# Altium schematic sheet Light Sensor.SchDoc
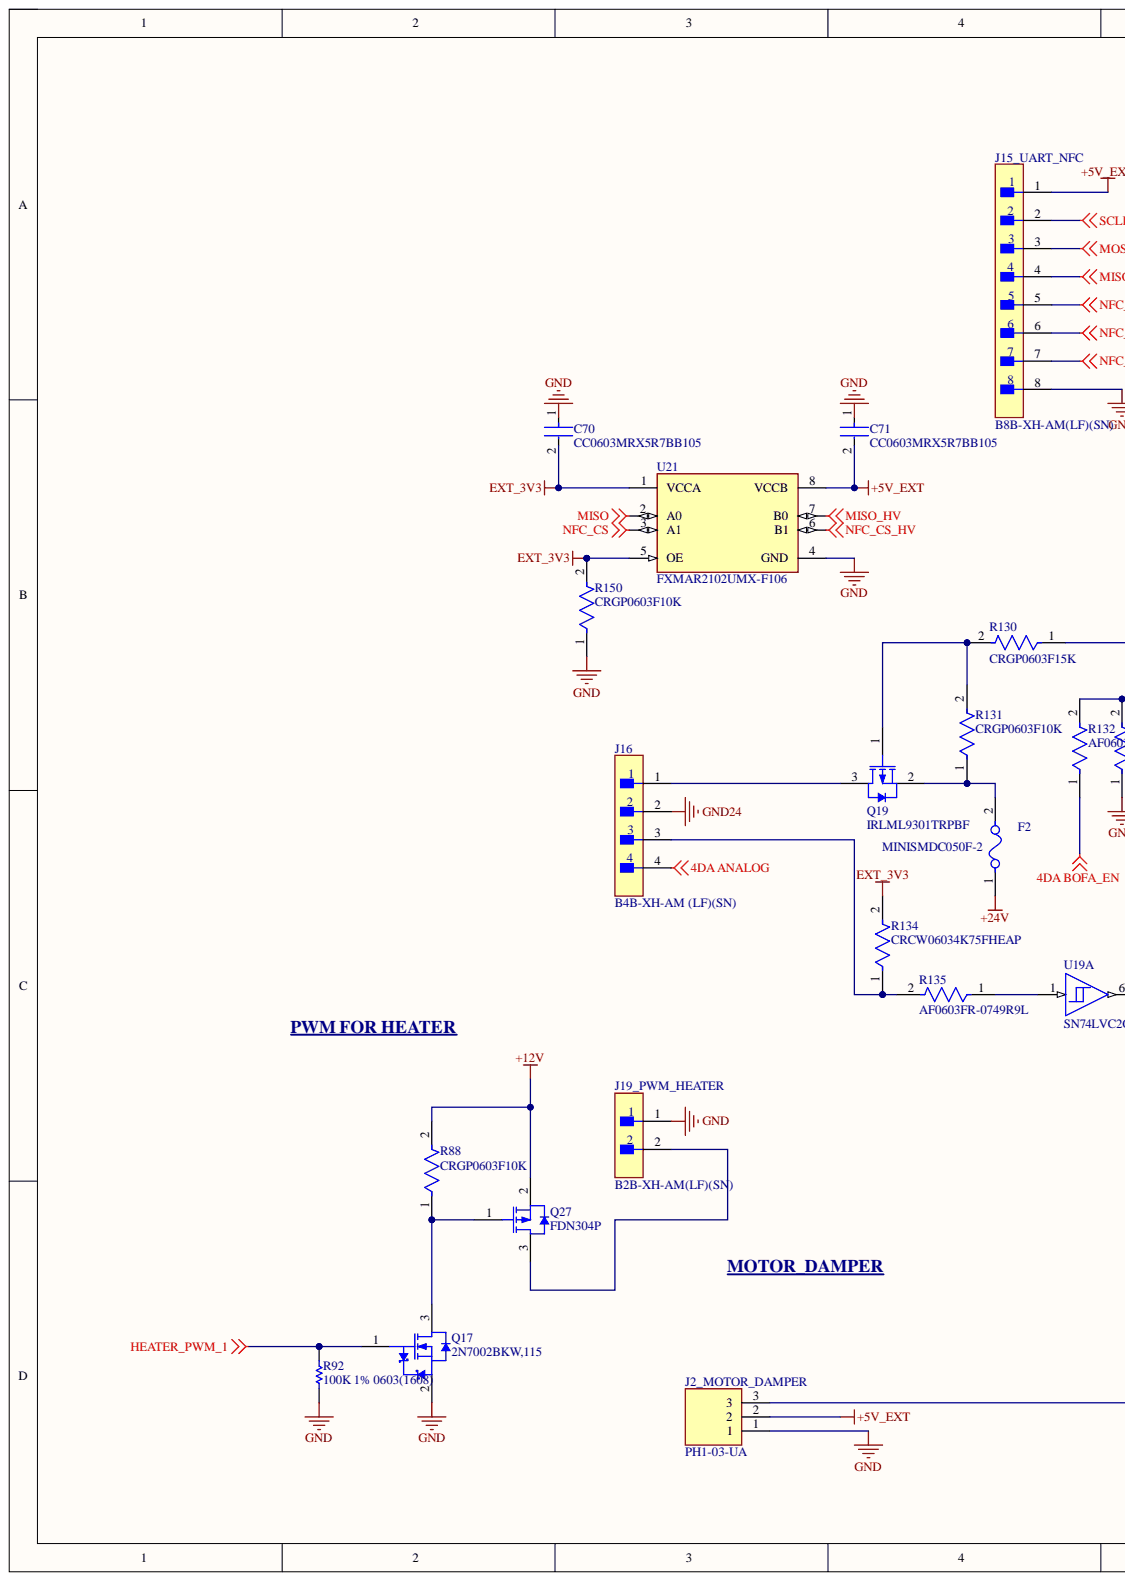
[diagram p0: Light Sensor.SchDoc, left]
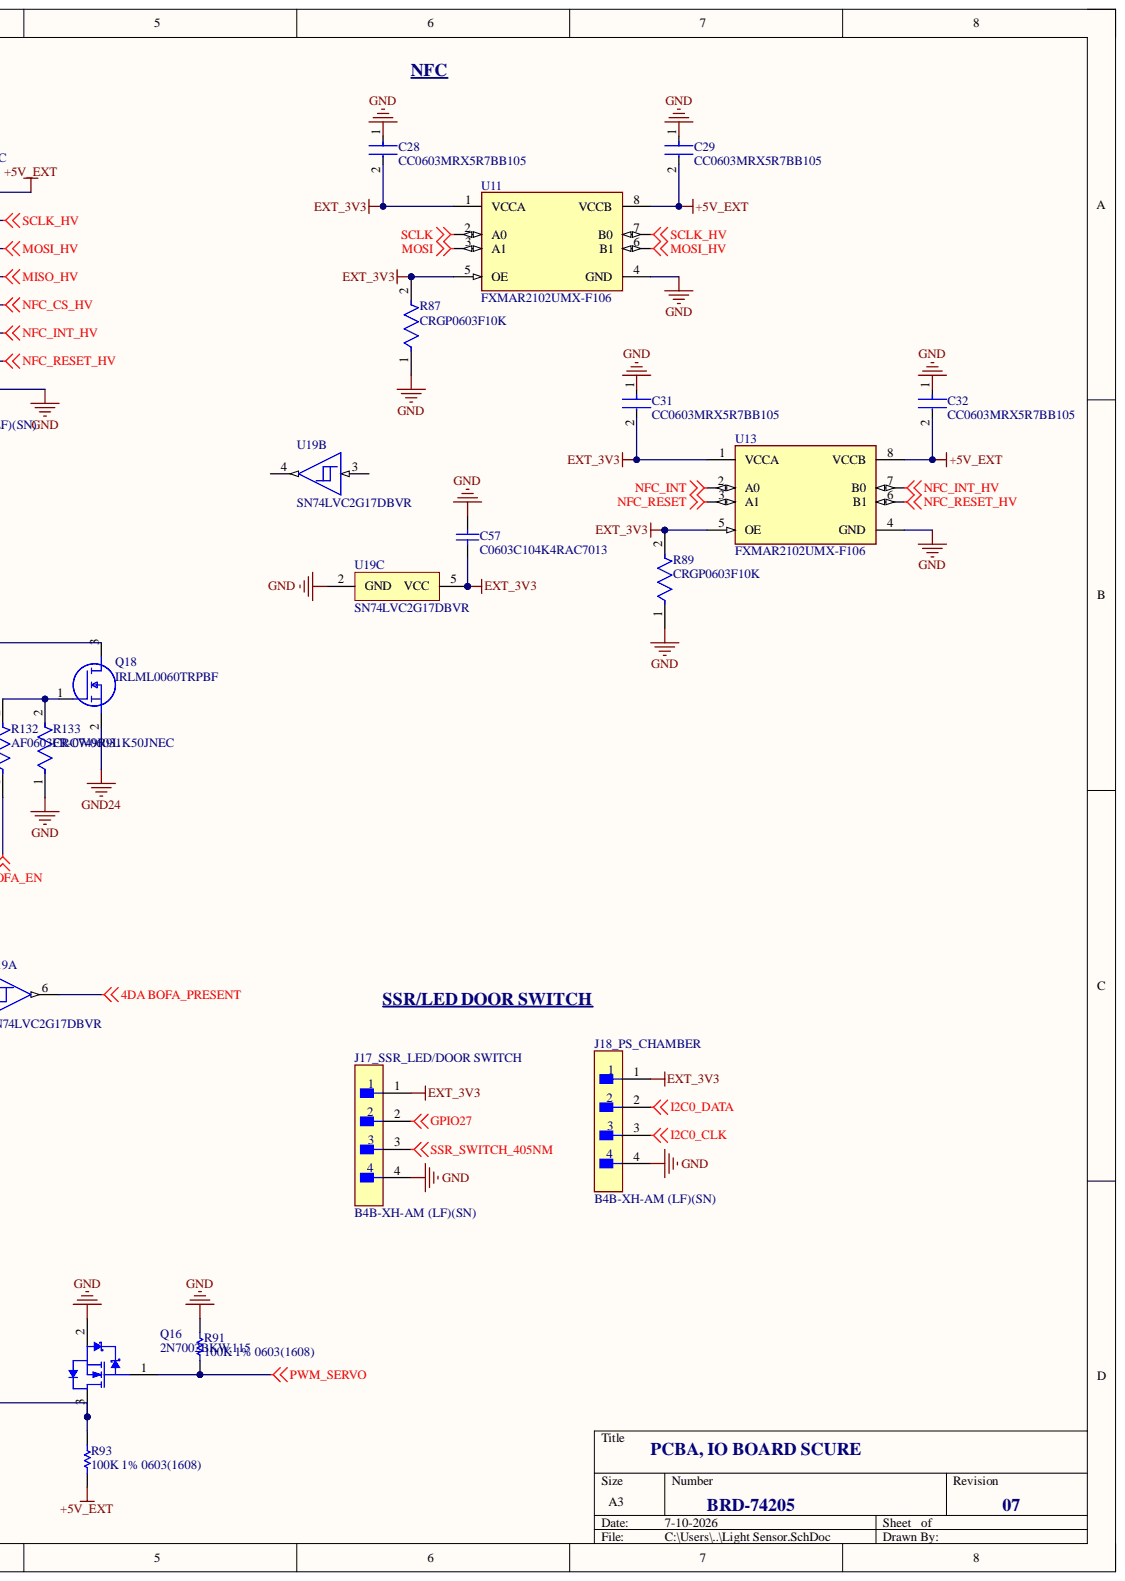
[diagram p1: Light Sensor.SchDoc, right]

## Parts
C28  CC0603MRX5R7BB105  [FP-CC0603-DA-MFG]
C29  CC0603MRX5R7BB105  [FP-CC0603-DA-MFG]
C31  CC0603MRX5R7BB105  [FP-CC0603-DA-MFG]
C32  CC0603MRX5R7BB105  [FP-CC0603-DA-MFG]
C57  C0603C104K4RAC7013  [CAPC1608X90X35ML15T15]
C70  CC0603MRX5R7BB105  [FP-CC0603-DA-MFG]
C71  CC0603MRX5R7BB105  [FP-CC0603-DA-MFG]
F2  MINISMDC050F-2  [FP-MINISMDC050F-MFG]
J2_MOTOR_DAMPER  PH1-03-UA  [HDRV3W64P0X254_1X3_762X250X952P]
J15_UART_NFC  B8B-XH-AM(LF)(SN)  [FP-B8B-XH-AM_LF_SN-MFG]
J16  B4B-XH-AM (LF)(SN)  [FP-B4B-XH-AM_LF_SN-MFG]
J17_SSR_LED/DOOR_SWITCH  B4B-XH-AM (LF)(SN)  [FP-B4B-XH-AM_LF_SN-MFG]
J18_PS_CHAMBER  B4B-XH-AM (LF)(SN)  [FP-B4B-XH-AM_LF_SN-MFG]
J19_PWM_HEATER  B2B-XH-AM(LF)(SN)  [FP-B2B-XH-AM_LF_SN-MFG]
Q16  2N7002BKW,115  [NXP-SOT323_N]
Q17  2N7002BKW,115  [NXP-SOT323_N]
Q18  IRLML0060TRPBF  [SOT95P237X112-3N]
Q19  IRLML9301TRPBF  [INF-SOT-23-3_V]
Q27  FDN304P  [FAIR-SSOT3L-3_V]
R87  CRGP0603F10K  [FP-CRGP0603-IPC_C]
R88  CRGP0603F10K  [FP-CRGP0603-IPC_C]
R89  CRGP0603F10K  [FP-CRGP0603-IPC_C]
R91  100K 1% 0603(1608)  [RESC0603(1608)_L]
R92  100K 1% 0603(1608)  [RESC0603(1608)_L]
R93  100K 1% 0603(1608)  [RESC0603(1608)_L]
R130  CRGP0603F15K  [FP-CRGP0603-IPC_C]
R131  CRGP0603F10K  [FP-CRGP0603-IPC_C]
R132  AF0603FR-0749R9L  [FP-AF0603-IPC_C]
R133  CRCW06031K50JNEC  [FP-CRCW0603-e3-IPC_A]
R134  CRCW06034K75FHEAP  [FP-CRCW0603-Pe3-IPC_B]
R135  AF0603FR-0749R9L  [FP-AF0603-IPC_C]
R150  CRGP0603F10K  [FP-CRGP0603-IPC_C]
U11  FXMAR2102UMX-F106  [FP-523AS-MFG]
U13  FXMAR2102UMX-F106  [FP-523AS-MFG]
U19  SN74LVC2G17DBVR  [DBV0006A_L]  (parts A,B,C)
U21  FXMAR2102UMX-F106  [FP-523AS-MFG]

## Nets
4DA ANALOG: J16.4
4DA BOFA_EN: R132.1
4DA BOFA_PRESENT: U19.6
+5V_EXT: C29.2 C32.2 C71.2 J2_MOTOR_DAMPER.2 J15_UART_NFC.1 R93.2 U11.8(VCCB) U13.8(VCCB) U21.8(VCCB)
+12V: Q27.2 R88.2
+24V: F2.1
EXT_3V3: C28.2 C31.2 C57.1 C70.2 J17_SSR_LED/DOOR_SWITCH.1 J18_PS_CHAMBER.1 R87.2 R89.2 R134.2 R150.2 U11.1(VCCA) U11.5(OE) U13.1(VCCA) U13.5(OE) U19.5(VCC) U21.1(VCCA) U21.5(OE)
GND: C28.1 C29.1 C31.1 C32.1 C57.2 C70.1 C71.1 J2_MOTOR_DAMPER.1 J15_UART_NFC.8 J17_SSR_LED/DOOR_SWITCH.4 J18_PS_CHAMBER.4 J19_PWM_HEATER.1 Q16.2 Q17.2 R87.1 R89.1 R91.1 R92.1 R133.1 R150.1 U11.4(GND) U13.4(GND) U19.2(GND) U21.4(GND)
GND24: J16.2 Q18.2
GPIO27: J17_SSR_LED/DOOR_SWITCH.2
HEATER_PWM_1: Q17.1 R92.2
I2C0_CLK: J18_PS_CHAMBER.3
I2C0_DATA: J18_PS_CHAMBER.2
MISO: U21.2(A0)
MISO_HV: J15_UART_NFC.4 U21.7(B0)
MOSI: U11.3(A1)
MOSI_HV: J15_UART_NFC.3 U11.6(B1)
NFC_CS: U21.3(A1)
NFC_CS_HV: J15_UART_NFC.5 U21.6(B1)
NFC_INT: U13.2(A0)
NFC_INT_HV: J15_UART_NFC.6 U13.7(B0)
NFC_RESET: U13.3(A1)
NFC_RESET_HV: J15_UART_NFC.7 U13.6(B1)
PWM_SERVO: Q16.1 R91.2
SCLK: U11.2(A0)
SCLK_HV: J15_UART_NFC.2 U11.7(B0)
SSR_SWITCH_405NM: J17_SSR_LED/DOOR_SWITCH.3
NetF2_2: F2.2 Q19.2 R131.1
NetJ2_MOTOR_DAMPER_3: J2_MOTOR_DAMPER.3 Q16.3 R93.1
NetJ16_1: J16.1 Q19.3
NetJ16_3: J16.3 R134.1 R135.2
NetJ19_PWM_HEATER_2: J19_PWM_HEATER.2 Q27.3
NetQ17_3: Q17.3 Q27.1 R88.1
NetQ18_1: Q18.1 R132.2 R133.2
NetQ18_3: Q18.3 R130.1
NetQ19_1: Q19.1 R130.2 R131.2
NetR135_1: R135.1 U19.1

Not connected: U19 3 4

## Library data of the parts
C28 C29 C31 C32 C70 C71 = CMP-03422-000949-1: CAP CER 1UF 16V X5R 0603; Manufacturer: YAGEO; Material: Ceramic; Temperature Coefficient: 15%; Tolerance: 0.2; Automotive: No; Capacitance: 1 uF; Category: Cap; Component Kind: Standard; ComponentLink2Description: Datasheet; ComponentLink2URL: http://www.yageo.com/documents/recent/UPY-GPHC_X7R_6.3V-to-50V_18.pdf; Device Class L1: Passive Components; Device Class L2: Capacitors; Device Class L3: Ceramic Capacitors; Digikey Description: CAP CER 1UF 16V X5R 0603; Footprint Url: http://www.yageo.com/exep/pages/download/literatures/UPY-C_GEN_24.pdf; Height: 0.9mm; Lead Free: Yes; Library Name: Altium Content Vault; Manufacturer Part Number: CC0603MRX5R7BB105; Mouser Description: Multilayer Ceramic Capacitors MLCC - SMD/SMT 1.0uF 16V X5R 20%; Octopart: https://octopart.com/cc0603mrx5r7bb105-yageo-57080764; Package: CC0603-DA; RoHS: TRUE; Temperature: -55°C to +125°C; Temperature Characteristic: X5R; Temperature Range High: +125°C; Temperature Range Low: -55°C; Value: 1 uF; Voltage: 16 V; Voltage Rating: 16 V
C57 = CMP-2006-02299-1: Manufacturer: Kemet; Component Kind: Standard; Library Name: Altium Content Vault; Part Number: C0603C104K4RAC7013
F2 = CMP-08606-000031-1: PTC RESET FUSE 24V 500MA 1812; Manufacturer: Littelfuse; Current Rating: 100A; Hold Current: 0.5A; Resistance: 0.15-1Ω; Trip Current: 1A; Trip Time: 0.15s; Value: 0.15-1Ω; Ambient Temperature Range High: +85°C; Ambient Temperature Range Low: -40°C; Automotive: No; Category: Fuse; Component Kind: Standard; ComponentLink2Description: Datasheet; ComponentLink2URL: https://www.littelfuse.com/~/media/electronics/product_specifications/resettable_ptcs/littelfuse_ptc_minismdc050f_2_product_specification.pdf.pdf; Device Class L1: Circuit Protection; Device Class L2: PTC Resettable Fuses; Device Class L3: unset; Digikey Description: PTC RESET FUSE 24V 500MA 1812; Footprint Url: https://www.littelfuse.com/~/media/electronics/datasheets/resettable_ptcs/littelfuse_ptc_minismd_catalog_datasheet.pdf.pdf; Height: 0.62mm; Lead Free: Yes; Library Name: Altium Content Vault; Manufacturer Part Number: MINISMDC050F-2; Mouser Description: PTC Resettable Fuse 0.5A(hold) 1A(trip) 24VDC 100A 0.8W 0.15s 0.15Ohm SMD Solder Pad 4.5 x 3.2 mm T/R; Octopart: https://octopart.com/minismdc050f-2-littelfuse-74215011; Package: MINISMDC050F; RoHS: TRUE; Voltage Rating: 24V
J2_MOTOR_DAMPER = PH1-03-UA: Connector; Manufacturer_Name: Adam Tech; Arrow Part Number: PH1-03-UA; Arrow Price/Stock: https://www.arrow.com/en/products/ph1-03-ua/adam-tech?utm_currency=USD&region=europe; Component Kind: Standard; Datasheet Link: https://datasheet.datasheetarchive.com/originals/distributors/Datasheets_SAMA/34c52fbb4019bb4b288b881302863af3.pdf; Height: 9.516mm; Library Name: SamacSys.SchLib; Manufacturer_Part_Number: PH1-03-UA; Mouser Part Number: 737-PH1-03-UA; Mouser Price/Stock: https://www.mouser.co.uk/ProductDetail/Adam-Tech/PH1-03-UA?qs=HoCaDK9Nz5cktq3WKneQTQ%3D%3D
J15_UART_NFC = CMP-17439-000637-1: CONN HEADER VERT 8POS 2.5MM; Mated Stacking Heights: 9.8mm; Number of Positions: 8; Voltage Rating: 250V; Color: Green; Component Kind: Standard; Connector Type: Header; Contact Finish - Mating: TIN; Contact Material: Brass; Contact Plating: Tin; Contact Shape: Square; Contact Type: Male Pin; Fastening Type: Detent; Flammability Rating: UL94 V-0; Insulation Color: Natural; Insulation Material: Nylon; Lead Free: Lead Free; Library Name: Altium Content Vault; Mount: Through Hole; Number of Rows: 1; Packaging: Bulk; Pitch: 2.5mm; Series: XH; Termination: Press-Fit
J16 J17_SSR_LED/DOOR_SWITCH J18_PS_CHAMBER = CMP-17439-000117-1: CONN HEADER VERT 4POS 2.5MM; Contact Resistance: 20mR; Gender: Male; Mated Stacking Heights: 9.8mm; Max Current Rating: 3A; Max Voltage Rating (AC): 250V; Number of Positions: 4; Color: Green; Component Kind: Standard; Connector Type: Header; Contact Finish - Mating: TIN; Contact Material: Brass; Contact Plating: Copper; Contact Shape: Square; Contact Type: Male Pin; Depth: 5.75mm; Fastening Type: Detent; Flammability Rating: UL94 V-0; Housing Color: White; Housing Material: Polyamide; Insulation Color: Natural; Insulation Material: Nylon; Lead Free: Lead Free; Length: 12.4mm; Library Name: Altium Content Vault; Max Operating Temperature: 85°C; Min Operating Temperature: -25°C; Mount: Through Hole; Number of Pins: 4; Number of Rows: 1; Orientation: Straight; Packaging: Bulk; Pitch: 2.5mm; Series: XH; Termination: Solder; Voltage Rating: 250V
J19_PWM_HEATER = CMP-17439-000037-1: CONN HEADER VERT 2POS 2.5MM; Connector Type: Male Pin; Contact Resistance: 20mR; Max Current Rating: 3A; Max Voltage Rating (AC): 250V; Number of Positions: 2; Color: Green; Component Kind: Standard; Contact Material: Brass; Contact Plating: Tin; Contact Type: Male Pin; Depth: 5.75mm; Fastening Type: Detent; Housing Color: White; Housing Material: Polyamide; Lead Free: Lead Free; Length: 7.4mm; Library Name: Altium Content Vault; Max Operating Temperature: 85°C; Min Operating Temperature: -25°C; Mount: Through Hole; Number of Rows: 1; Orientation: Straight; Packaging: Bulk; Pitch: 2.5mm; Series: XH; Termination: Solder
Q16 Q17 = CMP-1259-00106-1: N-Channel Trench MOSFET, 60 V, 0.31 A, -55 to 150 degC, 3-Pin SOT323, Tape and Reel; Manufacturer: NXP Semiconductors; Automotive Qualified: Y; Channel Type: N; ID [max] (A): 0.31; IDM [max] (A): 1.2; Packing: Tape & Reel; VDS [max] (V): 60; VGSth [typ] (V): 1.6; Code_JEITA: SC-70; Component Kind: Standard; ComponentLink1Description: Manufacturer URL; ComponentLink1URL: http://www.nxp.com/; ComponentLink2Description: Datasheet; ComponentLink2URL: http://www.nxp.com/documents/data_sheet/2N7002BKW.pdf; ComponentLink3Description: Package Specification; ComponentLink3URL: http://www.nxp.com/documents/outline_drawing/sot323_po.pdf; DatasheetVersion: Rev. 1; Library Name: Altium Content Vault; Mounting Technology: Surface Mount; PackageDescription: 3-Pin Small Outline Transistor Package, Body 2 x 1.25 mm, Pitch 0.65 mm; PackageReference: SOT323; PackageVersion: 06-03-16, 03/2006; PartNumber: 2N7002BKW,115
Q18 = IRLML0060TRPBF: MOSFET (N-Channel); Manufacturer_Name: Infineon; Arrow Part Number: IRLML0060TRPBF; Arrow Price/Stock: https://www.arrow.com/en/products/irlml0060trpbf/infineon-technologies-ag?utm_currency=USD&region=europe; Component Kind: Standard; Datasheet Link: https://www.infineon.com/dgdl/irlml0060pbf.pdf?fileId=5546d462533600a40153566493ef25e6; Height: 1.12mm; Manufacturer_Part_Number: IRLML0060TRPBF; Mouser Part Number: 942-IRLML0060TRPBF; Mouser Price/Stock: https://www.mouser.co.uk/ProductDetail/Infineon-Technologies/IRLML0060TRPBF?qs=9%252BKlkBgLFf3sC4ri8n%252Bt9Q%3D%3D
Q19 = CMP-2000-06487-1: HEXFET(R) Power MOSFET, 3.6 A, 30 V, -55 to 150 degC, 3-Pin SOT-23, RoHS, Tape and Reel; Manufacturer: InfineonTechnologies; Current - Continuous Drain (Id) @ 25°C: 3.6A(Ta); Drain to Source Voltage (Vdss): 30V; FET Feature: Standard; FET Type: MOSFETP-Channel,MetalOxide; Gate Charge (Qg) @ Vgs: 4.8nC@4.5V; Input Capacitance (Ciss) @ Vds: 388pF@25V; Power - Max: 1.3W; Rds On (Max) @ Id, Vgs: 64mOhm@3.6A,10V; Vgs(th) (Max) @ Id: 2.4V@10µA; Category: DiscreteSemiconductorProducts; Component Kind: Standard; Datasheet URL: http://www.infineon.com/dgdl/irlml9301pbf.pdf?fileId=5546d462533600a401535668e5e42640; Datasheet Version: 02/2012; Family: Transistors-FETs,MOSFETs-Single; Library Name: Altium Content Vault; Manufacturer URL: http://www.infineon.com/; Mounting Technology: SurfaceMount; Mounting Type: SurfaceMount; Operating Temperature: -55°C~150°C(TJ); Package / Case: TO-236-3,SC-59,SOT-23-3; Package Description: 3-Pin Small Outline Transistor, Body 2.92 x 1.3 mm, Pitch 0.95 mm; Package Reference: SOT-23-3; Packaging: Tape&Reel(TR); Part Status: Active; Series: HEXFET®; Supplier Device Package: Micro3™/SOT-23
Q27 = CMP-2000-06247-1: P-Channel Specified Power Trench(R) MOSFET, 1.8 V, -55 to 150 degC, 3-Pin SSOT, RoHS, Tape and Reel; Manufacturer: Fairchild Semiconductor; Continuous Drain Current Id: 2.4A; Current Temperature: 25°C; Drain Source Voltage Vds: 20V; External Depth: 2.5mm; External Length / Height: 1.12mm; External Width: 3.05mm; No. of Pins: 3; On Resistance Rds(on): 52mohm; Package Version: Rev. 3, 05/2015; Power Dissipation Pd: 500mW; Pulse Current Idm: 10A; Rds(on) Test Voltage Vgs: -4.5V; Reel Quantity: 3000; SMD Marking: 304P; SVHC: NoSVHC(19-Dec-2012); Threshold Voltage Vgs Typ: -800mV; Transistor Case Style: SOT-23; Transistor Polarity: PChannel; Voltage Vds Typ: -20V; Voltage Vgs th Max: -1.5V; Component Kind: Standard; Current Id Max: 2.4A; Datasheet URL: https://www.fairchildsemi.com/datasheets/FD/FDN304P.pdf; Datasheet Version: Rev. C, 01/2001; Full Power Rating Temperature: 25°C; Library Name: Altium Content Vault; Manufacturer URL: https://www.fairchildsemi.com/; Mounting Technology: SurfaceMount; Operating Temperature Max: 150°C; Operating Temperature Min: -55°C; Operating Temperature Range: -55°Cto+150°C; Package / Case: SOT-23; Package Description: 3-Pin Small Outline Transistor, Body 2.92 x 1.4 mm, Pitch 0.95 mm; Package Reference: SSOT3L; Package URL: https://www.fairchildsemi.com/package-drawings/MA/MA03B.pdf; Tape Width: 8mm; Termination Type: SMD; Voltage Vds: 20V; Voltage Vgs Max: -800mV; Voltage Vgs Rds on Measurement: -4.5V
R87-R89 R131 R150 = CMP-03211-004414-1: CRGP 0603 10K 1%; Manufacturer: TE; Power Rating: 0.25W; Resistance: 10KΩ; Temperature Coefficient: ±100ppm/°C; Tolerance: 0.01; Value: 10KΩ; Voltage Rating: 50V; Ambient Temperature Range High: +70°C; Automotive: No; Category: Res; Component Kind: Standard; ComponentLink2Description: Datasheet; ComponentLink2URL: https://www.te.com/commerce/DocumentDelivery/DDEController?Action=srchrtrv&DocNm=9-1773463-9&DocType=DS&DocLang=English; Composition: Thick Film; Device Class L1: Passive Components; Device Class L2: Resistors; Device Class L3: Chip SMD Resistors; Digikey Description: CRGP 0603 10K 1%; Height: 0.55mm; Ipc Land Pattern Name: RESC16080X45; Lead Free: Yes; Library Name: Altium Content Vault; Manufacturer Part Number: CRGP0603F10K; Mouser Description: Res, 10K, 1%, 0.25W, 0603, Thick Film; Octopart: https://octopart.com/crgp0603f10k-te+connectivity-91018721; Package: CRGP0603; RoHS: TRUE; Series: CRGP; Temperature: -55°C to +155°C; Temperature Range High: +155°C; Temperature Range Low: -55°C
R91-R93 = CMP-1012-00736-1: 100K 0.1W 1% 0603 (1608 Metric)  SMD; Case-EIA: 0603; Case-Metric: 1608; Value: 100000.00 Ohm; Component Kind: Standard; Library Name: Altium Content Vault; Power: 0.1 W; Technology: SMT; Tolerance: 1 %
R130 = CMP-03211-008902-1: CRGP0603 15KΩ 0.25W ±100ppm/°C 1% 50V; Manufacturer: TE; Tolerance: 0.01; Ambient Temperature Range High: +70°C; Automotive: No; Category: Res; Component Kind: Standard; ComponentLink2Description: Datasheet; ComponentLink2URL: https://www.te.com/commerce/DocumentDelivery/DDEController?Action=srchrtrv&DocNm=9-1773463-9&DocType=DS&DocLang=English; Composition: Thick Film; Device Class L1: Passive Components; Device Class L2: Resistors; Device Class L3: Chip SMD Resistors; Height: 0.55mm; Ipc Land Pattern Name: RESC16080X45; Lead Free: Yes; Library Name: Altium Content Vault; Manufacturer Part Number: CRGP0603F15K; Octopart: https://octopart.com/crgp0603f15k-te+connectivity-91018729; Package: CRGP0603; Power Rating: 0.25W; Resistance: 15KΩ; RoHS: TRUE; Series: CRGP; Temperature: -55°C to +155°C; Temperature Coefficient: ±100ppm/°C; Temperature Range High: +155°C; Temperature Range Low: -55°C; Value: 15KΩ; Voltage Rating: 50V
R132 R135 = CMP-03412-040151-1: Anti-Sulfurated Chip Resistors Automotive Grade 49.9Ω 1% 100ppm/°C 0603; Max Power Dissipation: 100mW; Resistance: 49.9R; Case/Package: 0603; Component Kind: Standard; Features: Moisture Resistant; Height: 0.022inch; Length: 0.063inch; Library Name: Altium Content Vault; Max Operating Temperature: 155°C; Min Operating Temperature: -55°C; Number of Terminations: 2; Packaging: Tape and Reel; Resistor Type: Thick Film; RoHS Compliant: Yes; Series: AF; Temperature Coefficient: 100ppm/°C; Tolerance: 1%; Width: 0.031inch
R133 = CMP-02407-012215-1: RES Thick Film, 1.5kΩ, 5%, 0.1W, 200ppm/°C, 0603; Max Power Dissipation: 100mW; Resistance: 1.5kR; Case/Package: 0603; Component Kind: Standard; Depth: 0.85mm; Features: Automotive AEC-Q200; Height: 0.02inch; Lead Free: Lead Free; Length: 0.061inch; Library Name: Altium Content Vault; Max Operating Temperature: 155°C; Military Standard: Not; Min Operating Temperature: -55°C; Number of Terminations: 2; Package Quantity: 20000; Packaging: Tape and Reel; Resistor Type: Thick Film; RoHS Compliant: Yes; Temperature Coefficient: 200ppm/°C; Tolerance: 5%; Width: 0.033inch
R134 = CMP-03613-000120-1: RES Thick Film, 4.75kΩ, 1%, 0.1W, 50ppm/°C, 0603; Max Power Dissipation: 100mW; Resistance: 4.75kR; Case/Package: 0603; Component Kind: Standard; Contact Plating: Tin; Features: Automotive AEC-Q200; Height: 0.02inch; Length: 0.061inch; Library Name: Altium Content Vault; Max Operating Temperature: 155°C; Min Operating Temperature: -55°C; Number of Terminations: 2; Package Quantity: 5000; Packaging: Tape and Reel; Radiation Hardening: No; Resistor Type: Thick Film; RoHS Compliant: Yes; Series: CRCW-P; Temperature Coefficient: 50ppm/°C; Tolerance: 1%; Width: 0.033inch
U11 U13 U21 = CMP-07110-000007-1: IC TRNSLTR BIDIRECTIONAL 8MLP; Number of Circuits: 1; Channel Type: Bidirectional; Component Kind: Standard; Library Name: Altium Content Vault; Max Operating Temperature: 85°C; Min Operating Temperature: -40°C; Mount: Surface Mount; Number of Pins: 8; Packaging: Tape and Reel
U19 = CMP-0859-00225-4: Dual Schmitt-Trigger Buffer, DBV0006A, LARGE T&R; Manufacturer: Texas Instruments; 3-State Output: No; Bits(#): 2; F @ Nom Voltage(Max)(Mhz): 150; ICC @ Nom Voltage(Max)(mA): 0.01; Input Type: CMOS/TTL; Logic: True; Output Drive (IOL/IOH)(Max)(mA): 32/-32; Output Type: CMOS; Rating: Catalog; Schmitt Trigger: Yes; Sub-Family: Non-Inverting Buffer/Driver; Technology Family: LVC; tpd @ Nom Voltage(Max)(ns): 9.3,5.7,5.4,4.3; VCC(Max)(V): 5.5; VCC(Min)(V): 1.65; Voltage(Nom)(V): 1.8,2.5,3.3,5; Component Kind: Standard; DatasheetVersion: SCES381N; Generic Part Number: SN74LVC2G17; Library Name: Altium Content Vault; Operating Temperature Range(C): -40 to 125,-40 to 85; PackageReference: DBV0006A
